# Altium schematic sheet fridge_module.SchDoc
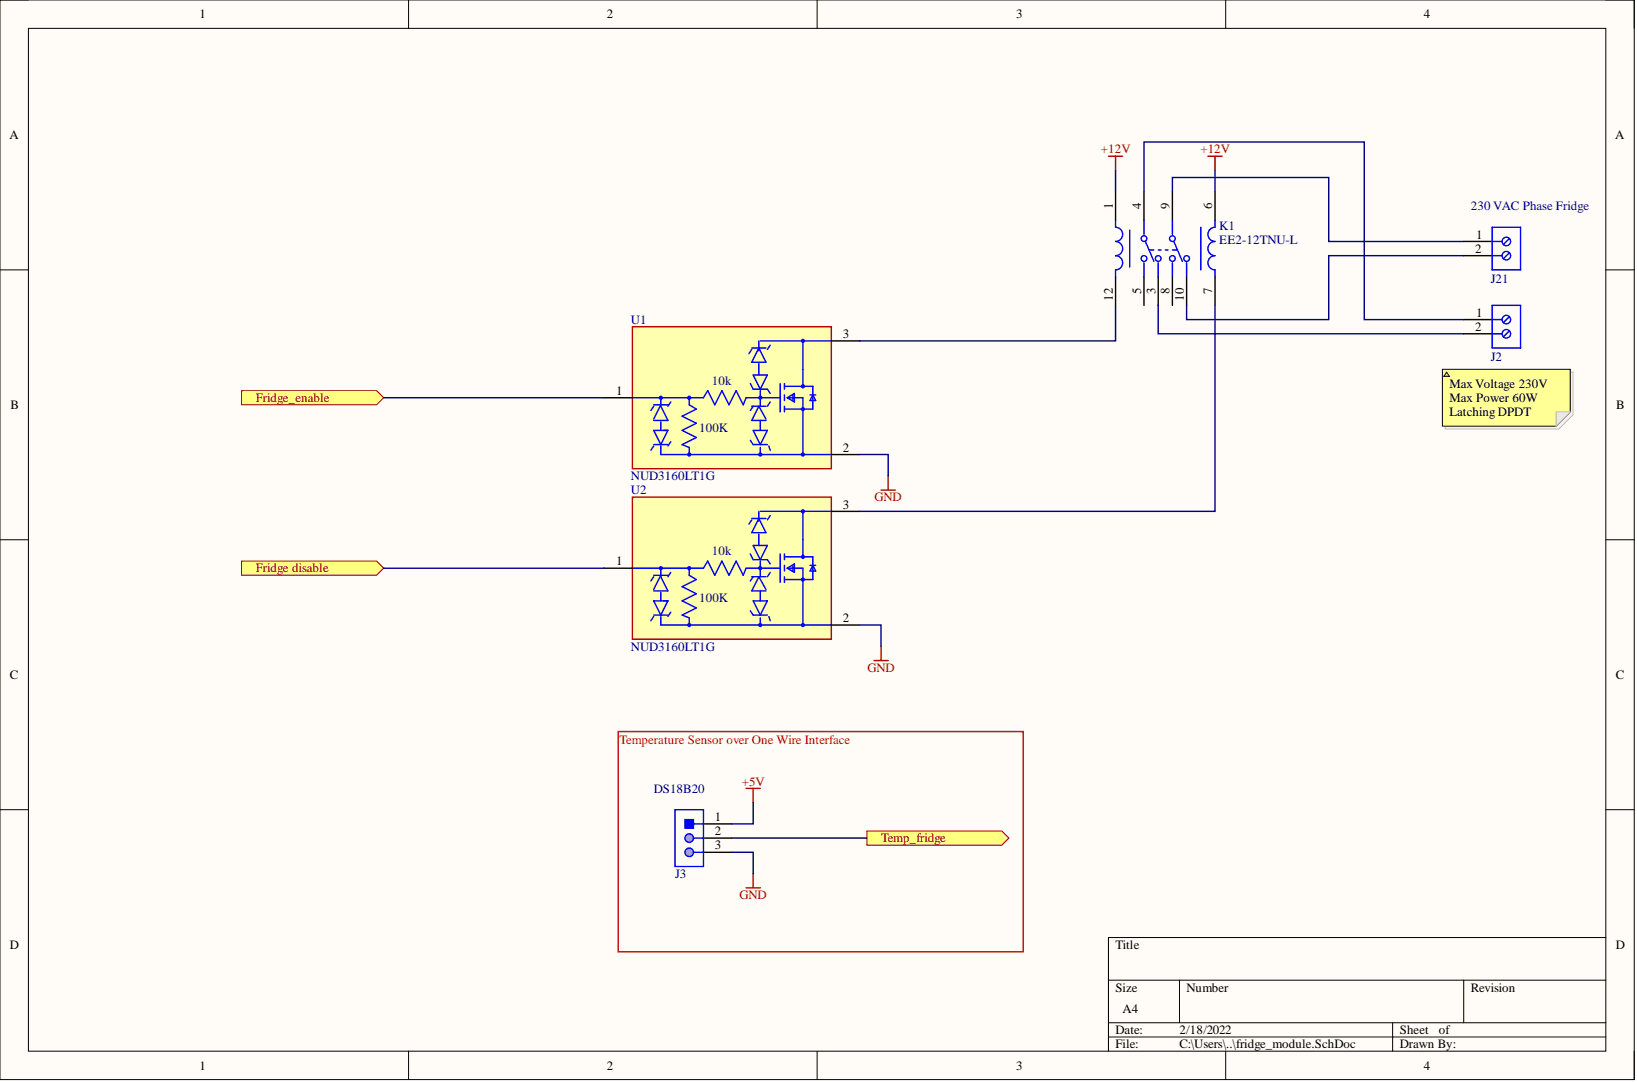
[diagram p0: fridge_module.SchDoc]

## Parts
J2  282836-2  [TECO-282836-2_V]  (value not drawn)
J3  JST-S3B-PH-SM4-TB-3_V  [JST-S3B-PH-SM4-TB-3_V]  (value not drawn)
J21  282836-2  [TECO-282836-2_V]  (value not drawn)
K1  EE2-12TNU-L  [FP-EE2-12TNU-L-MFG]
U1  NUD3160LT1G  [FP-318-08-MFG]
U2  NUD3160LT1G  [FP-318-08-MFG]

## Ports
Fridge disable, Fridge_enable, Temp_fridge

## Nets
+5V: J3.1
+12V: K1.1 K1.6
GND: J3.3 U1.2 U2.2
Fridge disable: U2.1 ; port Fridge disable
Fridge_enable: U1.1 ; port Fridge_enable
Temp_fridge: J3.2 ; port Temp_fridge
NetJ2_1: J2.1 K1.4
NetJ2_2: J2.2 K1.3
NetJ21_1: J21.1 K1.9
NetJ21_2: J21.2 K1.10
NetK1_7: K1.7 U2.3
NetK1_12: K1.12 U1.3

Not connected: K1 5 8

## Library data of the parts
J2 J21 = CMP-1684-00017-1: Female Header, Pitch 5 mm, 1 x 2 Position, Height 10 mm, Tail Length 3.5 mm, Rohs, Bulk; Manufacturer: TE Connectivity; 3D Model: 282836-2.pdf; Clamp Material - Plating: Brass-NickelPlated; Current: 13.5A; Dynamic Catalog: BuchananSeries; Featured Product: EurostyleTerminalBlockConnectors; Mating Orientation: HorizontalwithBoard; Number of Levels: 1; Other Names: 5ULV-02B  A98076; Pitch: 0.197"(5.00mm); Positions Per Level: 2; Product Training Modules: Wire-to-BoardEurostyleTerminalBlocks; Screw Thread: M3; Torque - Screw: 0.68Nm(6Lb-In); Voltage: 300V; Wire Gauge: 16-30AWG; Wire Termination: Screw-RisingCageClamp; Category: Connectors,Interconnects; Color: Green; Component Kind: Standard; Contact Material - Plating: Brass-TinPlated; Datasheet URL: http://www.te.com.cn/commerce/DocumentDelivery/DDEController?Action=showdoc&DocId=Catalog+Section%7F1308389_EUROSTYLE_TERMINAL_BLOCKS%7F0607%7Fpdf%7FEnglish%7FENG_CS_1308389_EUROSTYLE_TERMINAL_BLOCKS_0607.pdf%7F282836-2; Family: TerminalBlocks-WiretoBoard; Features: Interlocking(Side); Housing Material: Polyamide(PA66),Nylon6/6; Library Name: Altium Content Vault; Manufacturer URL: http://www.te.com; Mounting Type: ThroughHole; Package Description: Female Header, Pitch 5 mm, 1 x 2 Position, Height 10 mm, Tail Length 3.5 mm; Package Reference: THD; Packaging: Bulk; RoHS Information: 282836-2StatementofCompliance; Screw Material - Plating: Brass-NickelPlated; Series: Buchanan; Standard Package: 300
J3 = CONNECTOR_JST-S3B-PH-SM4: Component Kind: Standard; Description: JST-S3B-PH-SM4-TB-3_V; Library Name: integrated_library.IntLib
K1 = CMP-03195-000019-1: RELAY GEN PURPOSE DPDT 2A 12VDC; Coil Current: 11.7mA; Coil Power: 140mW; Coil Resistance: 1.028kR; Coil Type: Latching, Dual Coil; Coil Voltage (DC): 12V; Max Voltage Rating (AC): 250V; Max Voltage Rating (DC): 220V; Number of Poles: 1; Operate Time: 2ms; Relay Type: General Purpose; Release Time: 1ms; Component Kind: Standard; Contact Current Rating: 2A; Contact Material: Gold; Current Rating: 2A; Depth: 7.5mm; Height: 9mm; Length: 15mm; Library Name: Altium Content Vault; Max Current Rating: 2A; Max Operating Temperature: 85°C; Min Operating Temperature: -40°C; Mount: Surface Mount; Number of Pins: 10; Package Quantity: 500; Packaging: Tape and Reel; Power Consumption: 140mW; Radiation Hardening: No; REACH SVHC: No SVHC; RoHS Compliant: Yes; Termination: Gull Wing; Throw Configuration: DPDT
U1 U2 = CMP-07123-000002-1: IC INDCT LOAD DRVR INDUST SOT23; Manufacturer: ON Semiconductor; Fall Time: 622ns; Max Junction Temp: +150°C; Max Power Dissipation: 225mW; Max Supply Voltage: 60V; Number Of Drivers: 1; Output Current: 150mA; Output Voltage: 48V; Propagation Delay: 1160ns; Rise Time: 600ns; Standoff Height: 0.01mm; Ambient Temperature Range High: +125°C; Ambient Temperature Range Low: -40°C; Automotive: No; Category: IC; Component Kind: Standard; ComponentLink2Description: Datasheet; ComponentLink2URL: https://www.onsemi.com/pub/Collateral/NUD3160-D.PDF; Device Class L1: Integrated Circuits (ICs); Device Class L2: Power Management ICs; Device Class L3: Gate Drivers; Digikey Description: IC INDCT LOAD DRVR INDUST SOT23; Footprint Url: https://www.onsemi.com/pub/Collateral/318-08.PDF; Height: 1.11mm; Lead Free: Yes; Library Name: Altium Content Vault; Manufacturer Part Number: NUD3160LT1G; Mouser Description: MOSFET 61V Industrial Load Driver; Number Of Outputs: 1; Octopart: https://octopart.com/nud3160lt1g-on+semiconductor-470723; Package: 318-08; RoHS: TRUE; Temperature: -40°C to +150°C; Temperature Range High: +150°C; Temperature Range Low: -40°C
